# Altium schematic sheet Vichydro_TOP.SchDoc(Vichydro_TOP)
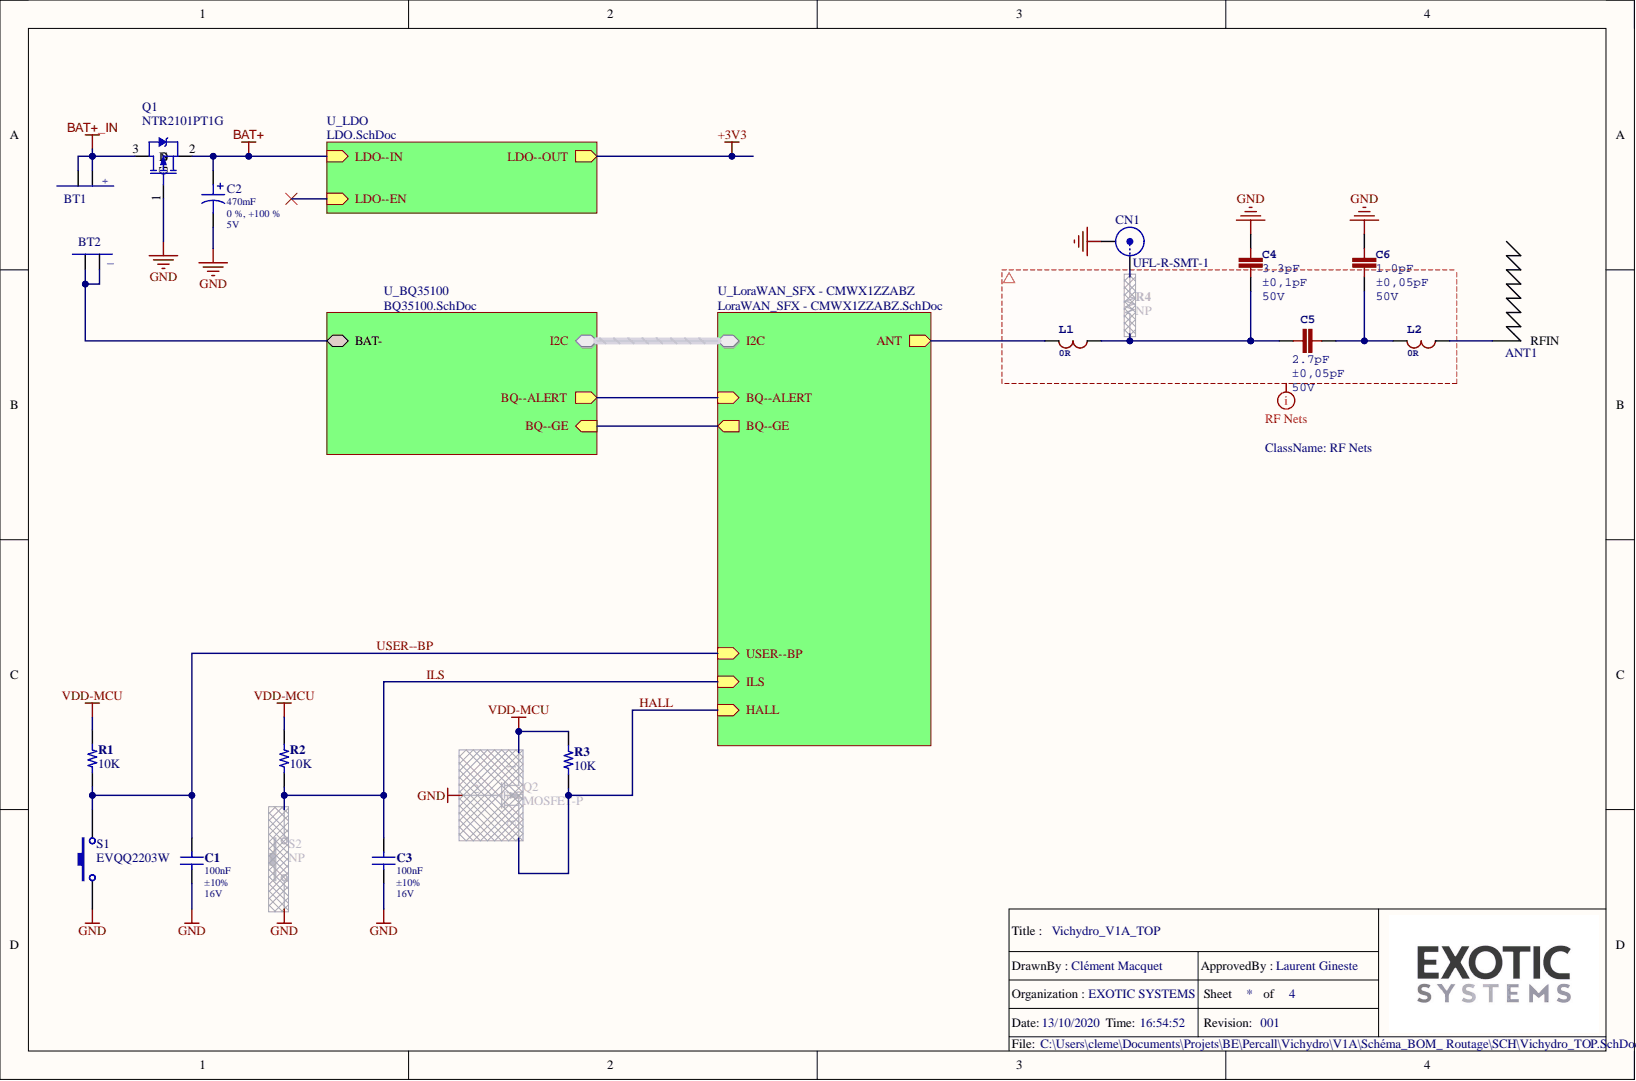
[diagram p0: Vichydro_TOP.SchDoc]

## Parts
ANT1  ANT_PCB_868MHz_Helico  [ANT_PCB_868MHz_Helico]  (value not drawn)  (no BOM)
BT1  KEYSTONE 92_PLUS  [KEYSTONE 92]  (value not drawn)
BT2  KEYSTONE 92_MOINS  [KEYSTONE 92]  (value not drawn)
C1  100nF  Max Voltage: 16V  Tolerance: ±10%  [CAP 0402/1005]
C2  470mF  Max Voltage: 5V  [SUPERCAP AVX SCMR14]
C3  100nF  Max Voltage: 16V  Tolerance: ±10%  [CAP 0402/1005]
C4  value 3.3pF  Max Voltage: 50V  Tolerance: ±0,1pF  [CAP 0402/1005 RF]  (hidden comment: Capacitor RF)
C5  value 2.7pF  Tolerance: ±0,05pF  [CAP 0402/1005 RF]  (hidden comment: Capacitor RF)
C6  value 1.0pF  Max Voltage: 50V  Tolerance: ±0,05pF  [CAP 0402/1005 RF]  (hidden comment: Capacitor RF)
CN1  UFL  [UFL-R-SMT-1]
L1  value 0R  [IND 0402/1005 RF]  (hidden comment: Inductor RF)
L2  value 0R  [IND 0402/1005 RF]  (hidden comment: Inductor RF)
Q1  PMOS-2  [SOT23-3 ONSEMI]  (value not drawn)
Q2  MOSFET-P  [E3]
R1  10K  [RES 0402/1005]
R2  10K  [RES 0402/1005]
R3  10K  [RES 0402/1005]
R4  NP  [RES 0402/1005]
S1  KMR2  [EVQQ2203W]  (value not drawn)
S2  NP  [GENERIC_ILS]

## Nets
BAT+: C2.1 Q1.2
BAT+_IN: BT1.1 BT1.2 Q1.3
GND: C1.2 C2.2 C3.2 C4.2 C6.2 CN1.2 Q1.1 Q2.2 S1.1 S2.1
VDD-MCU: Q2.1 R1.2 R2.2 R3.2
HALL: Q2.3 R3.1
ILS: C3.1 R2.1 S2.2
USER--BP: C1.1 R1.1 S1.2
NetANT1_1: ANT1.1 L2.1
NetBT2_1: BT2.1 BT2.2
NetC4_1: C4.1 C5.1 L1.1 R4.1
NetC5_2: C5.2 C6.1 L2.2
NetCN1_1: CN1.1 R4.2
NetL1_2: L1.2
+3V3:
BQ--ALERT:
BQ--GE:
I2C.I2C-SCL:
I2C.I2C-SDA:
LDO--EN:

## Library data of the parts
ANT1 = ANTPCB-868MHZ: ANT PCB 868MHZ; Manufacturer: Exotic; Database Table Name: Antenna_RF; Operating Frequency: 868 MHz, 915 MHz; Param-S Path: S param\Other\; Project1: SIDV4; Project2: ExoPIR; State: Active; Datasheet Path: Datasheets\; Designator: ANT?; Library Name: Exotic.DbLib; Manufacturer Part Number: ANTPCB-868MHZ; Part Number: ANTPCB-868MHZ; Published: 06/12/2018; Stock: 0; Publisher: EXOTIC(CMA)
BT1 = 92(+): BAT CONTACT CLIP A/AA TH; Manufacturer: Keystone Electronics; Manufacturer Part Number: 92; Database Table Name: Battery; Project1: Depthbox; State: Active; Component Kind: Standard; Component Type: Battery Clip; Datasheet Path: Datasheets\92.pdf; DatasheetDocument: 19/05/2020; Designator: BT?; Height: 15,06mm; Mounting Style: SMD; Operating Temperature: -50 °C ~ 245 °C; Part Number: 92(+); Pin Count: 2; Published: 19/05/2020; Stock: 0; Library Name: Exotic.DbLib; Publisher: Exotic(CMA)
BT2 = 92(-): BAT CONTACT CLIP A/AA TH; Manufacturer: Keystone Electronics; Manufacturer Part Number: 92; Database Table Name: Battery; Project1: Depthbox; State: Active; Component Kind: Standard; Component Type: Battery Clip; Datasheet Path: Datasheets\92.pdf; DatasheetDocument: 19/05/2020; Designator: BT?; Height: 15,06mm; Mounting Style: SMD; Operating Temperature: -50 °C ~ 245 °C; Part Number: 92(-); Pin Count: 2; Published: 19/05/2020; Stock: 0; Library Name: Exotic.DbLib; Publisher: Exotic(CMA)
C1 C3 = C0402 100NF: CAP CER 100NF ±10% 16V X7R 0402 SMD; Manufacturer Part Number Passives: CC0402KRX7R7BB104; Database Table Name: Capacitors; PackageReference (inch): 0402; State: Active; Type: Capacitor; Component Kind: Standard; Designator: C?; Height: 0,55 mm; Part Number: C0402 100NF; Pin Count: 2; Stock: 0; Temp Coeff: X7R; Value: 100nF; Library Name: Exotic.DbLib; Publisher: EXOTIC(CMA)
C2 = SCMR14C474PRBA0: CAP CER 0.47F ±10% ??V X7R 0603 SMD; Manufacturer: AVX; Database Table Name: Capacitors; PackageReference (inch): TH; Tolerance: 0 %, +100 %; Type: Capacitor; Component Kind: Standard; Datasheet Path: Datasheets\SCMR14C474PRBA0-AVX-datasheet-80907929; DatasheetDocument: 06/10/2020; Designator: C?; Height: 15,5mm; Manufacturer Part Number: SCMR14C474PRBA0; Part Number: SCMR14C474PRBA0; Pin Count: 2; Published: 06/10/2020; Stock: 0; Value: 470mF; Library Name: Exotic.DbLib; Publisher: EXOTIC(CMA)
C4 = GJM1555C1H3R3BB01: CAP CER 3.3PF ±0.1PF 50V C0G 0402 SMD; Manufacturer: Murata; Manufacturer Part Number: GJM1555C1H3R3BB01D; C vs Freq Path: Z:\Hardware\Altium\Bibliothèque Altium\C_vs_freq\csv\; CrossRef1: GCM1555C1H3R3BA16D; Database Table Name: Capacitors_RF; DC Bias Path: Z:\Hardware\Altium\Bibliothèque Altium\V bias\CSV\; PackageReference (inch): 0402; Param-S Path (Serie): Z:\Hardware\Altium\Bibliothèque Altium\S Param\Serie\s2p\; Param-S Path (Shunt): Z:\Hardware\Altium\Bibliothèque Altium\S Param\Shunt\s2p\; State: Active; Component Kind: Standard; Designator: C?; Height: 0,55 mm; Part Number: GJM1555C1H3R3BB01; Pin Count: 2; Published: 22/10/2019; Stock: 0; Type: Capacitor RF; Datasheet Path: Z:\Hardware\Altium\Bibliothèque Altium\Datasheets\; Library Name: Exotic.DbLib; Publisher: EXOTIC(CMA)
C5 = GJM1555C1H2R7WB01: CAP CER 2.7PF ±0.05PF 50V C0G 0402 SMD; Manufacturer: Murata; Manufacturer Part Number: GJM1555C1H2R7WB01D; C vs Freq Path: C_vs_freq\csv\GRM1555C1H2R7CA01D.csv; Database Table Name: Capacitors_RF; PackageReference (inch): 0402; Param-S Path (Serie): S_Param\Serie\s2p\GRM1555C1H2R7CA01_series.s2p; Param-S Path (Shunt): S_Param\Shunt\s2p\GRM1555C1H2R7CA01_shunt.s2p; State: Active; Component Kind: Standard; Datasheet Path: Datasheets\GRM1555C1H2R7CA01D.pdf; DatasheetDocument: 07-May-2018; Designator: C?; Height: 0,55 mm; Max Voltage: 50V; Part Number: GJM1555C1H2R7WB01; Pin Count: 2; Stock: 0; Type: Capacitor RF; Library Name: Exotic.DbLib; Publisher: EXOTIC(CMA)
C6 = GJM1555C1H1R0WB01: CAP CER 1PF ±0.05PF 50V C0G 0402 SMD; Manufacturer: Murata; Manufacturer Part Number: GJM1555C1H1R0WB01D; C vs Freq Path: C_vs_freq\csv\GRM1555C1H2R7CA01D.csv; Database Table Name: Capacitors_RF; PackageReference (inch): 0402; Param-S Path (Serie): S_Param\Serie\s2p\GRM1555C1H2R7CA01_series.s2p; Param-S Path (Shunt): S_Param\Shunt\s2p\GRM1555C1H2R7CA01_shunt.s2p; Project1: SuperTracker; State: Active; Component Kind: Standard; Datasheet Path: Datasheets\GRM1555C1H2R7CA01D.pdf; DatasheetDocument: 07-May-2018; Designator: C?; Height: 0,55 mm; Part Number: GJM1555C1H1R0WB01; Pin Count: 2; Stock: 0; Type: Capacitor RF; Library Name: Exotic.DbLib; Publisher: EXOTIC(CMA)
CN1 = UFL-R-SMT-1: CONN UFL SMD; Manufacturer: Hirose; Database Table Name: Connectors_RF; Freq Max: 6 GHz; Project1: P040 - BalizV1.A; Project2: P038 - DataLoggerV2.C; State: Active; Component Kind: Standard; Component Type: Plug; Designator: CN?; Implentation: SMD; LatestRevisionDate: 17-Jul-2002; LatestRevisionNote: Re-released for DXP Platform.; Manufacturer Part Number: UFL-R-SMT-1; Part Number: UFL-R-SMT-1; Pin Count: 3; Published: 07-May-2018; Signal Integrity: Connector; Stock: 0; Type: Plug; Library Name: Exotic.DbLib; Publisher: EXOTIC(CMA)
L1 L2 = IND 0402/1005 RF: RES 0R ±1% 1/16W 0402 SMD; Manufacturer Part Number Passives: RC0402JR-070RL; Database Table Name: Inductors_RF; PackageReference (inch): 0402; Component Kind: Standard; Component Type: Fixed Inductor; Designator: L?; Part Number: IND 0402/1005 RF; Pin Count: 2; Published: 22/03/2018; Stock: 0; Type: Inductor RF; Library Name: Exotic.DbLib; Publisher: EXOTIC(CMA)
Q1 = NTR2101PT1G: MOSFET P-CH 8V 3.7A SOT23-3 SMD; Manufacturer: On Semiconductor; Database Table Name: Transistors; Note: Pin 4 not drawn and electrically connected to Pin 2.; PackageReference (inch): SOT-23-3; State: Active; Type: MOSFET-P; Component Kind: Standard; ComponentLink1Description: Manufacturer Link; ComponentLink1URL: http://www.irf.com/; Datasheet Path: Datasheet\NTR2101PT1G; DatasheetDocument: 01/03/2006; DatasheetVersion: PD-9.390D; Designator: Q?; Height (mm): 1,1 mm; LatestRevisionDate: 17-Jul-2002; LatestRevisionNote: Re-released for DXP Platform.; Manufacturer Part Number: NTR2101PT1G; PackageDescription: TO, Thru-Hole, Vertical, Heatsink Mounted; 3 In-Line Leads; Pitch 2.54 mm; PackageReference: TO-220AB; PackageVersion: Feb-1997; Part Number: NTR2101PT1G; Pin Count: 3; Published: 09/07/2020; Stock: 0; Library Name: Exotic.DbLib; Publisher: EXOTIC(CMA)
Q2 = MOSFET-P: P-Channel MOSFET; Component Kind: Standard; LatestRevisionDate: 17-Jul-2002; LatestRevisionNote: Re-released for DXP Platform.; Library Name: Miscellaneous Devices.IntLib; PackageDescription: TO, Flat Index; 3 In-Line, Axial Leads; Body Dia. 4.6mm; Lead Dia. 0.5 mm (max); PackageReference: E3; PackageVersion: 1995/1996; Published: 8-Jun-2000; Simulation: PMOS; Publisher: Altium Limited
R1-R3 = R0402 10K: RES 10K ±1% 1/16W 0402 SMD; Manufacturer Part Number Passives: RC0402FR-0710KL; Database Table Name: Resistors; L*W: 1,0*0,5 mm; Power(W): 63mW; State: Active; Component Kind: Standard; Datasheet Path: Datasheets\; Designator: R?; Mounting Style: SMD; Operating Temperature: -55°C ~ 125°C; PackageReference: 0402; Part Number: R0402 10K; Pin Count: 2; Published: 18/01/2019; Stock: 0; Tolerance: ±1%; Type: Resistor; Value: 10K; Library Name: Exotic.DbLib; Publisher: EXOTIC(CMA)
R4 = R0402 0R: Manufacturer Part Number Passives: RC0402JR-070RL; Database Table Name: Resistors; L*W: 1,0*0,5 mm; Power(W): 63mW; State: Active; Tolerance: ±1%; Component Kind: Standard; Datasheet Path: Datasheets\; Description: NP; Designator: R?; Mounting Style: SMD; Operating Temperature: -55°C ~ 125°C; PackageReference: 0402; Part Number: R0402 0R; Pin Count: 2; Published: 18/01/2019; Stock: 0; Type: Resistor; Value: NP; Library Name: Exotic.DbLib; Publisher: EXOTIC(CMA)
S1 = EVQQ2203W: SWITCH TACT 1NO 20MA SMD; Manufacturer: Panasonic; Database Table Name: Switches; L*W: 6,6*6,0mm; Project1: Wand; State: Active; Component Kind: Standard; Component Type: Tactile Switch; Current Rating: 20mA; Datasheet Path: Datasheets\0900766b810ad242.pdf; Designator: SW?; Height: 3,1mm; LatestRevisionDate: 17-Jul-2002; LatestRevisionNote: Re-released for DXP Platform.; Manufacturer Part Number: EVQQ2203W; Mounting Style: SMD; PackageDescription: Switch; 2 Leads; PackageReference: SPST-2; Part Number: EVQQ2203W; Pin Count: 4; Published: 20/01/2020; Stock: 0; Library Name: Exotic.DbLib; Publisher: EXOTIC(CMA)
S2 = SW-PB: Component Kind: Standard; Description: NP; Library Name: Miscellaneous Devices.IntLib
